# Altium schematic sheet main.SchDoc
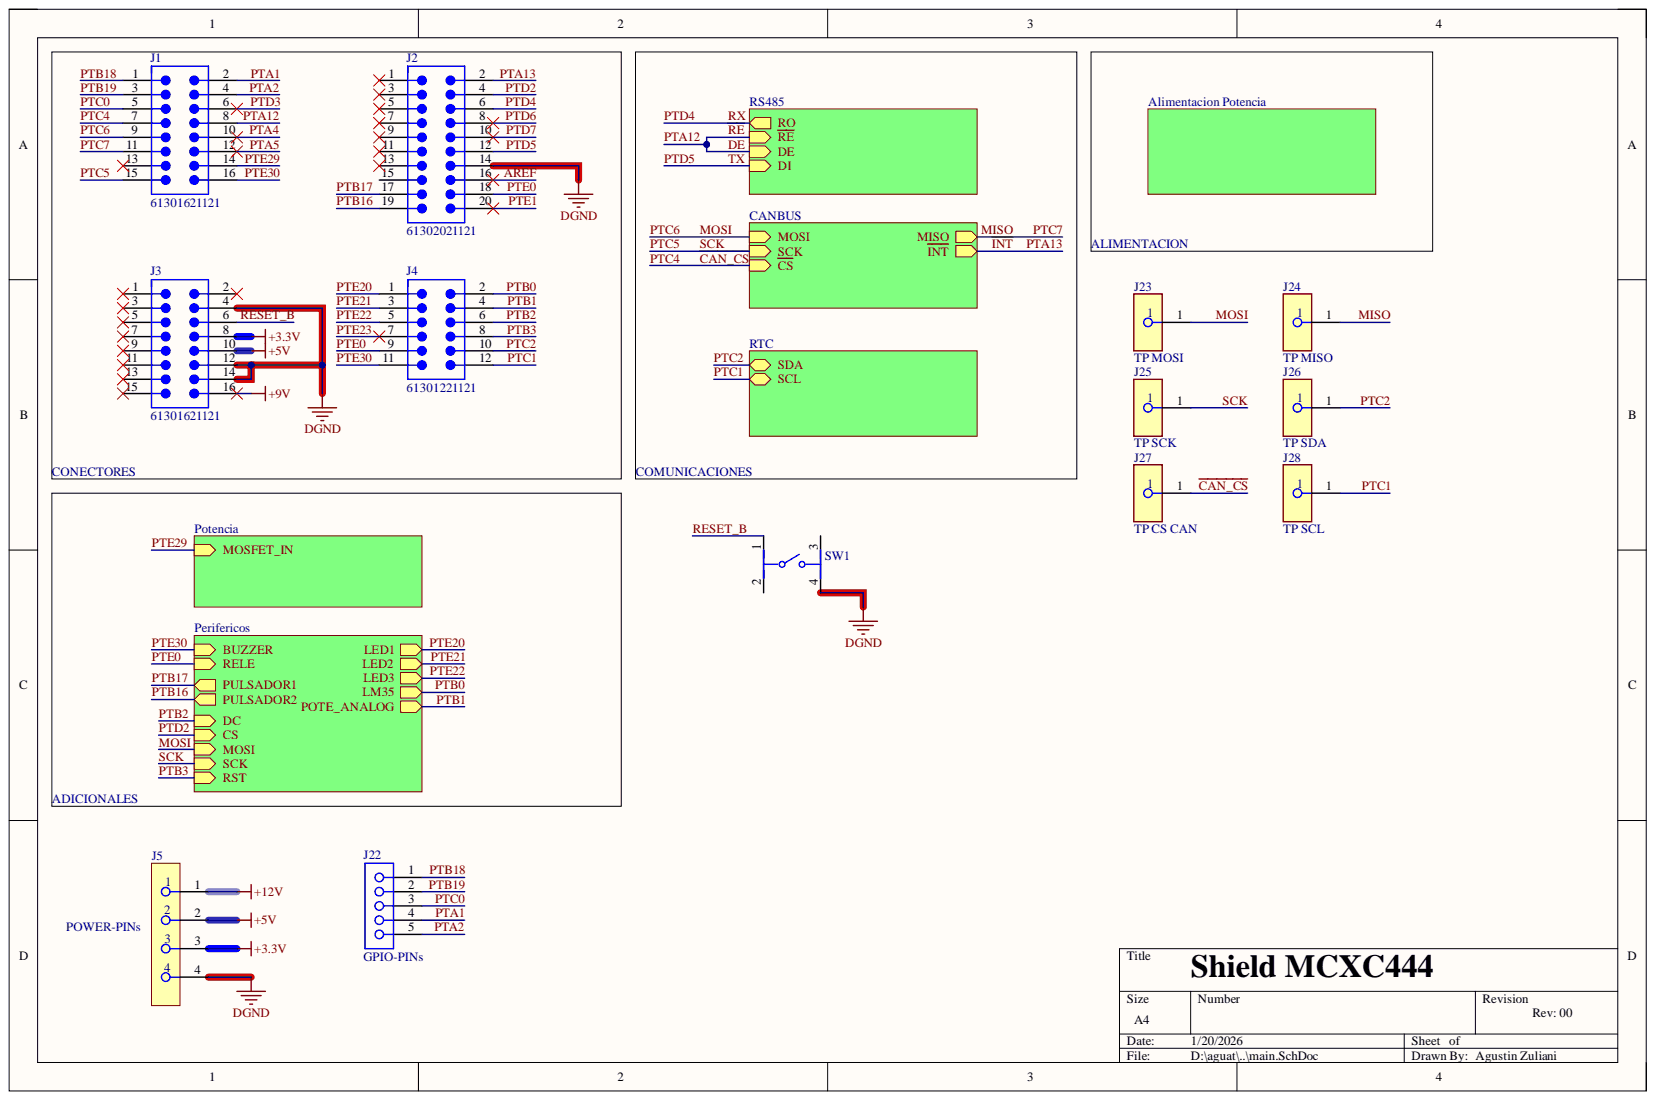
[diagram p0: main.SchDoc]

## Parts
J1  61301621121  [61301621121]
J2  61302021121  [61302021121]
J3  61301621121  [61301621121]
J4  61301221121  [61301221121]
J5  POWER-PINs  [FP-ESW-104-23-G-S-MFG]
J22  GPIO-PINs  [SMTC-SMH-105-02-X-S]
J23  TP MOSI  [FP-5004-MFG]
J24  TP MISO  [FP-5004-MFG]
J25  TP SCK  [FP-5004-MFG]
J26  TP SDA  [FP-5004-MFG]
J27  TP CS CAN  [FP-5004-MFG]
J28  TP SCL  [FP-5004-MFG]
SW1  1-1825910-4  [FP-1-1825910-4-MFG]  (value not drawn)

## Nets
+3.3V: J3.8 J5.3
+5V: J3.10 J5.2
+9V: J3.16
+12V: J5.1
DGND: J2.14 J3.4 J3.12 J3.14 J5.4 SW1.4
~{CAN_CS}: J1.7 J27.1
~{INT}: J2.2
~{RE}: J1.8
AREF: J2.16
MISO: J1.11 J24.1
MOSI: J1.9 J23.1
PTA1: J1.2 J22.4
PTA2: J1.4 J22.5
PTA4: J1.10
PTA5: J1.12
PTB0: J4.2
PTB1: J4.4
PTB2: J4.6
PTB3: J4.8
PTB16: J2.19
PTB17: J2.17
PTB18: J1.1 J22.1
PTB19: J1.3 J22.2
PTC0: J1.5 J22.3
PTC1: J4.12 J28.1
PTC2: J4.10 J26.1
PTC5: J1.15 J25.1
PTD2: J2.4
PTD3: J1.6
PTD4: J2.6
PTD5: J2.12
PTD6: J2.8
PTD7: J2.10
PTE0: J2.18 J4.9
PTE1: J2.20
PTE20: J4.1
PTE21: J4.3
PTE22: J4.5
PTE23: J4.7
PTE29: J1.14
PTE30: J1.16 J4.11
RESET_B: J3.6 SW1.1
NetJ1_13: J1.13
NetJ2_1: J2.1
NetJ2_3: J2.3
NetJ2_5: J2.5
NetJ2_7: J2.7
NetJ2_9: J2.9
NetJ2_11: J2.11
NetJ2_13: J2.13
NetJ2_15: J2.15
NetJ3_1: J3.1
NetJ3_2: J3.2
NetJ3_3: J3.3
NetJ3_5: J3.5
NetJ3_7: J3.7
NetJ3_9: J3.9
NetJ3_11: J3.11
NetJ3_13: J3.13
NetJ3_15: J3.15
NetSW1_2: SW1.2
NetSW1_3: SW1.3

## Library data of the parts
J1 J3 = CMP-1502-01097-3: NexarPartId: 32855550; Connector Type: Male Pin; Contact Resistance: 20mΩ; Current Rating: 3A; Gender: Male; Number of Contacts: 16; Operating Supply Voltage: 250V; Pitch: 2.54mm; Color: Black; Component Kind: Standard; Contact Material: Copper; Contact Mating Length: 5.9944mm; Contact Plating: Gold; Height: 8.89mm; Lead Pitch: 2.54mm; Library Name: Altium Content Vault; Max Operating Temperature: 105°C; Min Operating Temperature: -40°C; Mount: Through Hole; Number of Pins: 16; Number of Positions: 16; Number of Rows: 2; Orientation: Vertical; Packaging: Bulk; REACH SVHC: Unknown; RoHS: Compliant; Row Spacing: 2.54mm; Termination: Solder; Voltage Rating: 250V
J2 = CMP-1502-01104-3: NexarPartId: 32855556; Connector Type: Male Pin; Contact Resistance: 20mΩ; Current Rating: 3A; Gender: Male; Number of Contacts: 20; Operating Supply Voltage: 250V; Pitch: 2.54mm; Color: Black; Component Kind: Standard; Contact Material: Copper; Contact Mating Length: 5.9944mm; Contact Plating: Gold; Lead Pitch: 2.54mm; Library Name: Altium Content Vault; Mount: Through Hole; Number of Pins: 20; Number of Positions: 20; Number of Rows: 2; Orientation: Vertical; Packaging: Bulk; REACH SVHC: Unknown; RoHS: Compliant; Row Spacing: 2.54mm; Termination: Solder
J4 = CMP-1502-01088-4: NexarPartId: 32855540; Connector Type: Male Pin; Contact Resistance: 20mΩ; Current Rating: 3A; Gender: Male; Number of Contacts: 12; Operating Supply Voltage: 250V; Pitch: 2.54mm; Color: Black; Component Kind: Standard; Contact Material: Copper; Contact Mating Length: 5.9944mm; Contact Plating: Gold; Height: 8.89mm; Lead Pitch: 2.54mm; Library Name: Altium Content Vault; Max Operating Temperature: 105°C; Min Operating Temperature: -40°C; Mount: Through Hole; Number of Pins: 12; Number of Positions: 12; Number of Rows: 2; Orientation: Vertical; Packaging: Bulk; REACH SVHC: Unknown; RoHS: Compliant; Row Spacing: 2.54mm; Termination: Solder; Voltage Rating: 250V
J5 = CMP-00575-09268283-1: Conn Elevated Socket SKT 4 POS 2.54mm Solder ST Thru-Hole; Manufacturer Lifecycle Status: PRODUCTION; Contact Resistance: 10mΩ; Current Rating: 5.2A; Max Current Rating: 6.9A; Max Voltage Rating (AC): 550V; Number of Contacts: 4; Component Kind: Standard; Contact Material: Gold, Nickel; Contact Plating: Gold; Depth: 2.41mm; Height: 13.72mm; Housing Color: Black; Housing Material: Polyester; Length: 10.67mm; Library Name: Altium Content Vault; Lifecycle Status: Production; Max Operating Temperature: 125°C; Min Operating Temperature: -55°C; Number of Rows: 1; Orientation: Straight; Pitch: 2.54mm; Radiation Hardening: No; RoHS: Compliant; Termination: Solder
J22 = CMP-1023-00168-1: SMT Horizontal Socket, -55 to 125 degC, 2.54 mm Pitch, 5-Pin, Female, RoHS; Manufacturer: Samtec; PartNumber: SMH-105-02-G-S; Pitch: 2.54 mm; Component Kind: Standard; ComponentLink1Description: Manufacturer URL; ComponentLink1URL: http://www.samtec.com; ComponentLink2Description: Datasheet; ComponentLink2URL: http://www.samtec.com/documents/webfiles/pdf/SMH.PDF; ComponentLink3Description: Package Specification; ComponentLink3URL: http://www.samtec.com/documents/webfiles/cpdf/SMH-1XX-XX-XX-X-XX-MKT.pdf; DatasheetVersion: F-214; Library Name: Altium Content Vault; Mounting Technology: Surface Mount; Orientation: Horizontal; PackageDescription: .100 [2.54] Horizontal SMT Socket Assembly; PackageReference: SMH-105-02-X-S; PackageVersion: AF, 2/1999; RoHS: True; Temperature Range: -55 to 125 degC
J23-J28 = CMP-2000-05575-2: PC TEST POINT MINIATURE YELLOW; Manufacturer: Keystone; Manufacturer Part Number: 5004; Is Female: yes; Number Of Contacts: 1; Automotive: No; Category: Conn; Component Kind: Standard; ComponentLink2Description: Datasheet; ComponentLink2URL: https://www.keyelco.com/product-pdf.cfm?p=1313; Contact Material: Silver; Device Class L1: Connectors; Device Class L2: unset; Device Class L3: unset; Digikey Description: PC TEST POINT MINIATURE YELLOW; Height: 4.72mm; Lead Free: Yes; Library Name: Altium Content Vault; Mouser Description: TEST POINT PC MINI .040"D YELLOW; Octopart: https://octopart.com/5004-keystone-28591; Package: 5004; RoHS: TRUE
SW1 = CMP-03407-000002-1: SWITCH TACTILE SPST-NO 0.05A 24V; Manufacturer: TE Connectivity; Contact Current Rating: 50mA; Contact Resistance: 100mΩ; Electromechanical Life: 100000Cycles; Operating Force: 160gf; Automotive: No; Category: Switch; Component Kind: Standard; ComponentLink2Description: Datasheet; ComponentLink2URL: https://www.te.com/commerce/DocumentDelivery/DDEController?Action=showdoc&DocId=Customer+Drawing%7F1825910%7FC10%7Fpdf%7FEnglish%7FENG_CD_1825910_C10.pdf%7F1-1825910-4; Device Class L1: Electromechanical; Device Class L2: Switches; Device Class L3: Tactile Switches; Digikey Description: SWITCH TACTILE SPST-NO 0.05A 24V; Height: 9.8mm; Lead Free: Yes; Library Name: Altium Content Vault; Manufacturer Part Number: 1-1825910-4; Mount: Through Hole; Mouser Description: Tactile Switches 6MM ACTHIGH TEMP TACT SWITCH; Octopart: https://octopart.com/1-1825910-4-te+connectivity-42270468; Package: 1-1825910-4; RoHS: TRUE; Temperature: -35°C to +85°C; Temperature Range High: +85°C; Temperature Range Low: -35°C; Throw Configuration: SPST-NO; Voltage Rating Dc: 24V
